# Altium schematic sheet P03_WiFi 2 of 2.SchDoc
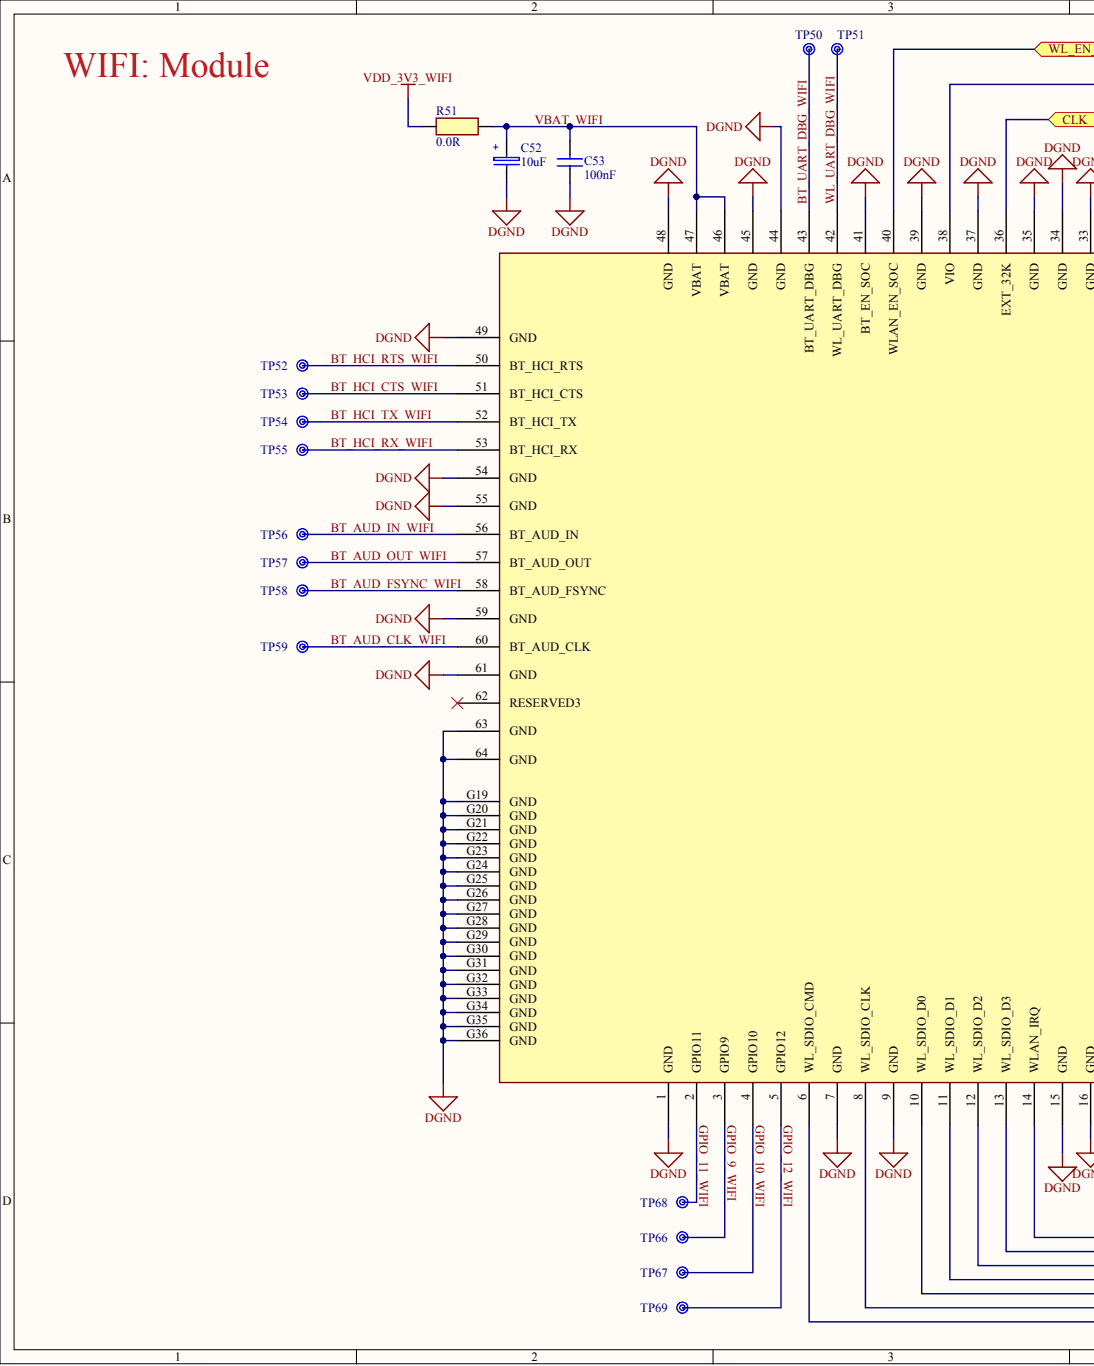
[diagram p0: P03_WiFi 2 of 2.SchDoc, left]
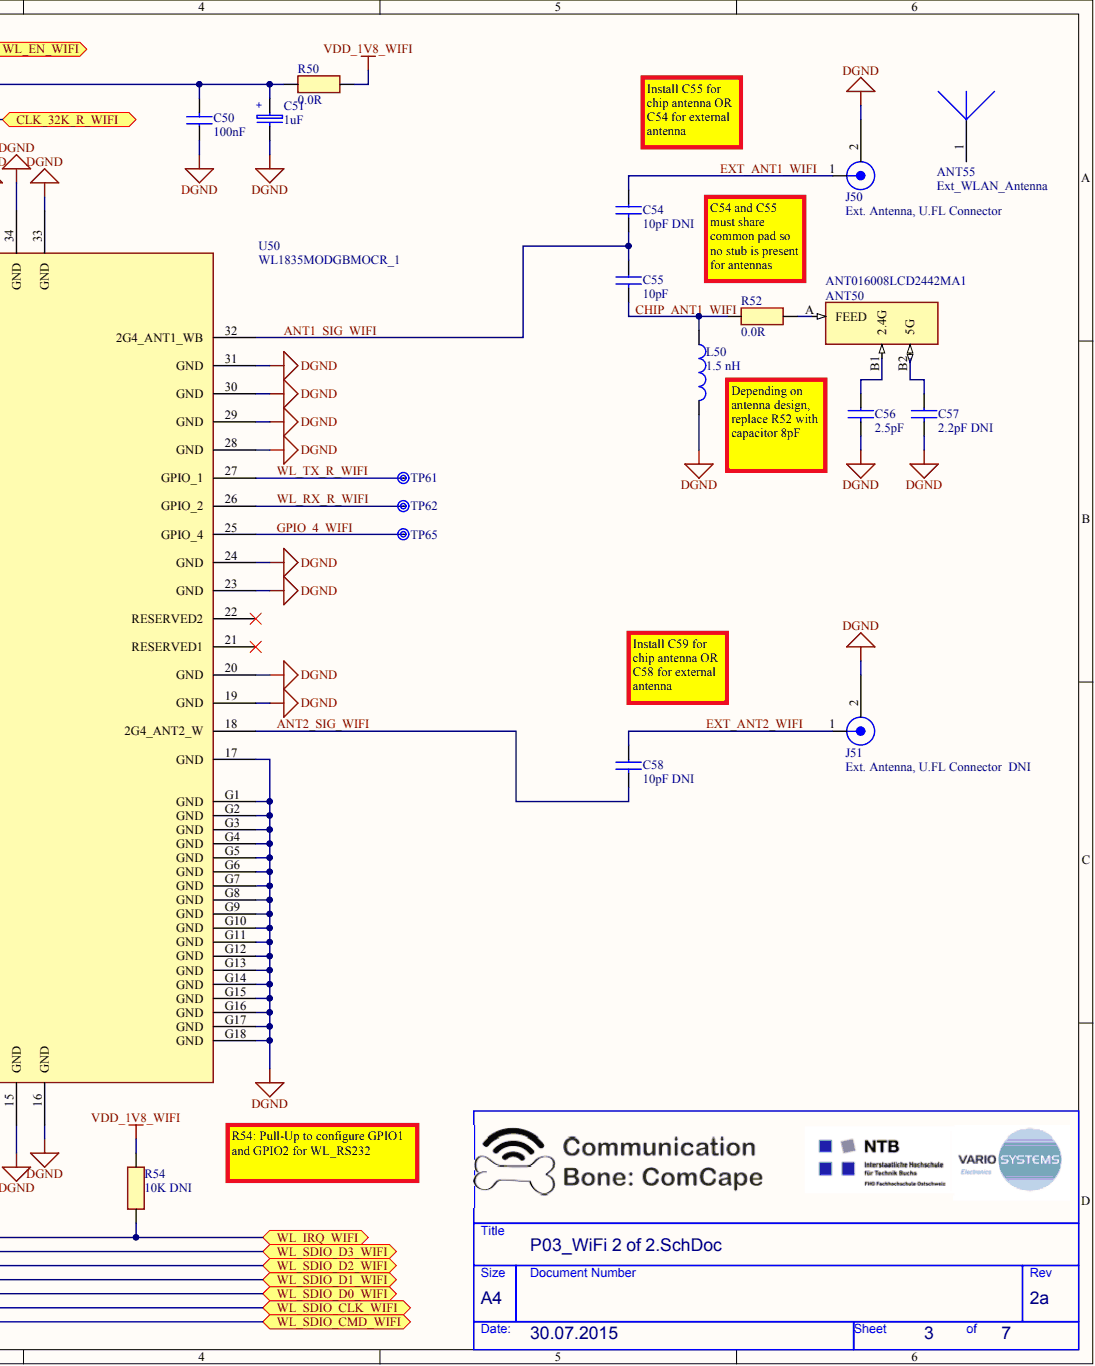
[diagram p1: P03_WiFi 2 of 2.SchDoc, right]

## Parts
ANT50  ANT016008LCD2442MA1  [ANT016008LCD2442MA1]
ANT55  Ext_WLAN_Antenna  (mechanical)
C50  100nF  [SM/C_0603]
C51  1uF  [SM/CP_3216]
C52  10uF  [SM/CP_3528]
C53  100nF  [SM/C_0603]
C54  10pF DNI  [SM/C_0402]
C55  10pF  [SM/C_0402]
C56  2.5pF  [SM_0402_WIFI]
C57  2.2pF DNI  [SM_0402_WIFI]
C58  10pF DNI  [SM/C_0402]
J50  Ext. Antenna, U.FL Connector  [U.FL_Ext-Ant-Connector]
J51  Ext. Antenna, U.FL Connector  DNI  [U.FL_Ext-Ant-Connector]
L50  1.5 nH  [SM/L_0402]
R50  0.0R  [SM/R_0603]
R51  0.0R  [SM/R_0603]
R52  0.0R  [SM/R_0402]
R54  10K DNI  value 10K  [SM/R_0603]
TP50  Testpoint mit Bohrung  [TP_mit_0.7mm_Bohrung]  (value not drawn)  (no BOM)
TP51  Testpoint mit Bohrung  [TP_mit_0.7mm_Bohrung]  (value not drawn)  (no BOM)
TP52  Testpoint mit Bohrung  [TP_mit_0.7mm_Bohrung]  (value not drawn)  (no BOM)
TP53  Testpoint mit Bohrung  [TP_mit_0.7mm_Bohrung]  (value not drawn)  (no BOM)
TP54  Testpoint mit Bohrung  [TP_mit_0.7mm_Bohrung]  (value not drawn)  (no BOM)
TP55  Testpoint mit Bohrung  [TP_mit_0.7mm_Bohrung]  (value not drawn)  (no BOM)
TP56  Testpoint mit Bohrung  [TP_mit_0.7mm_Bohrung]  (value not drawn)  (no BOM)
TP57  Testpoint mit Bohrung  [TP_mit_0.7mm_Bohrung]  (value not drawn)  (no BOM)
TP58  Testpoint mit Bohrung  [TP_mit_0.7mm_Bohrung]  (value not drawn)  (no BOM)
TP59  Testpoint mit Bohrung  [TP_mit_0.7mm_Bohrung]  (value not drawn)  (no BOM)
TP61  Testpoint mit Bohrung  [TP_mit_0.7mm_Bohrung]  (value not drawn)  (no BOM)
TP62  Testpoint mit Bohrung  [TP_mit_0.7mm_Bohrung]  (value not drawn)  (no BOM)
TP65  Testpoint mit Bohrung  [TP_mit_0.7mm_Bohrung]  (value not drawn)  (no BOM)
TP66  Testpoint mit Bohrung  [TP_mit_0.7mm_Bohrung]  (value not drawn)  (no BOM)
TP67  Testpoint mit Bohrung  [TP_mit_0.7mm_Bohrung]  (value not drawn)  (no BOM)
TP68  Testpoint mit Bohrung  [TP_mit_0.7mm_Bohrung]  (value not drawn)  (no BOM)
TP69  Testpoint mit Bohrung  [TP_mit_0.7mm_Bohrung]  (value not drawn)  (no BOM)
U50  WL1835MODGBMOCR_1  [WLAN_100Pin_MOC_E-13.4X13.3-N100_0.75-TOP]

## Ports
CLK_32K_R_WIFI, WL_EN_WIFI, WL_IRQ_WIFI, WL_SDIO_CLK_WIFI, WL_SDIO_CMD_WIFI, WL_SDIO_D0_WIFI, WL_SDIO_D1_WIFI, WL_SDIO_D2_WIFI, WL_SDIO_D3_WIFI

## Nets
DGND: C50.1 C51.N C52.N C53.1 C56.2 C57.2 J50.2 J51.2 L50.1 U50.1(GND) U50.7(GND) U50.9(GND) U50.15(GND) U50.16(GND) U50.17(GND) U50.19(GND) U50.20(GND) U50.23(GND) U50.24(GND) U50.28(GND) U50.29(GND) U50.30(GND) U50.31(GND) U50.33(GND) U50.34(GND) U50.35(GND) U50.37(GND) U50.39(GND) U50.41(BT_EN_SOC) U50.44(GND) U50.45(GND) U50.48(GND) U50.49(GND) U50.54(GND) U50.55(GND) U50.59(GND) U50.61(GND) U50.63(GND) U50.64(GND) U50.G1(GND) U50.G2(GND) U50.G3(GND) U50.G4(GND) U50.G5(GND) U50.G6(GND) U50.G7(GND) U50.G8(GND) U50.G9(GND) U50.G10(GND) U50.G11(GND) U50.G12(GND) U50.G13(GND) U50.G14(GND) U50.G15(GND) U50.G16(GND) U50.G17(GND) U50.G18(GND) U50.G19(GND) U50.G20(GND) U50.G21(GND) U50.G22(GND) U50.G23(GND) U50.G24(GND) U50.G25(GND) U50.G26(GND) U50.G27(GND) U50.G28(GND) U50.G29(GND) U50.G30(GND) U50.G31(GND) U50.G32(GND) U50.G33(GND) U50.G34(GND) U50.G35(GND) U50.G36(GND)
VDD_1V8_WIFI: R50.2 R54.2
VDD_3V3_WIFI: R51.1
ANT1_SIG_WIFI: C54.2 C55.1 U50.32(2G4_ANT1_WB)
ANT2_SIG_WIFI: C58.2 U50.18(2G4_ANT2_W)
BT_AUD_CLK_WIFI: TP59.1 U50.60(BT_AUD_CLK)
BT_AUD_FSYNC_WIFI: TP58.1 U50.58(BT_AUD_FSYNC)
BT_AUD_IN_WIFI: TP56.1 U50.56(BT_AUD_IN)
BT_AUD_OUT_WIFI: TP57.1 U50.57(BT_AUD_OUT)
BT_HCI_CTS_WIFI: TP53.1 U50.51(BT_HCI_CTS)
BT_HCI_RTS_WIFI: TP52.1 U50.50(BT_HCI_RTS)
BT_HCI_RX_WIFI: TP55.1 U50.53(BT_HCI_RX)
BT_HCI_TX_WIFI: TP54.1 U50.52(BT_HCI_TX)
BT_UART_DBG_WIFI: TP50.1 U50.43(BT_UART_DBG)
CHIP_ANT1_WIFI: C55.2 L50.2 R52.1
CLK_32K_R_WIFI: U50.36(EXT_32K) ; port CLK_32K_R_WIFI
EXT_ANT1_WIFI: C54.1 J50.1
EXT_ANT2_WIFI: C58.1 J51.1
GPIO_4_WIFI: TP65.1 U50.25(GPIO_4)
GPIO_9_WIFI: TP66.1 U50.3(GPIO9)
GPIO_10_WIFI: TP67.1 U50.4(GPIO10)
GPIO_11_WIFI: TP68.1 U50.2(GPIO11)
GPIO_12_WIFI: TP69.1 U50.5(GPIO12)
VBAT_WIFI: C52.P C53.2 R51.2 U50.46(VBAT) U50.47(VBAT)
WL_EN_WIFI: U50.40(WLAN_EN_SOC) ; port WL_EN_WIFI
WL_IRQ_WIFI: R54.1 U50.14(WLAN_IRQ) ; port WL_IRQ_WIFI
WL_RX_R_WIFI: TP62.1 U50.26(GPIO_2)
WL_SDIO_CLK_WIFI: U50.8(WL_SDIO_CLK) ; port WL_SDIO_CLK_WIFI
WL_SDIO_CMD_WIFI: U50.6(WL_SDIO_CMD) ; port WL_SDIO_CMD_WIFI
WL_SDIO_D0_WIFI: U50.10(WL_SDIO_D0) ; port WL_SDIO_D0_WIFI
WL_SDIO_D1_WIFI: U50.11(WL_SDIO_D1) ; port WL_SDIO_D1_WIFI
WL_SDIO_D2_WIFI: U50.12(WL_SDIO_D2) ; port WL_SDIO_D2_WIFI
WL_SDIO_D3_WIFI: U50.13(WL_SDIO_D3) ; port WL_SDIO_D3_WIFI
WL_TX_R_WIFI: TP61.1 U50.27(GPIO_1)
WL_UART_DBG_WIFI: TP51.1 U50.42(WL_UART_DBG)
NetANT50_A: ANT50.A R52.2
NetANT50_B1: ANT50.B1 C56.1
NetANT50_B2: ANT50.B2 C57.1
NetC50_2: C50.2 C51.P R50.1 U50.38(VIO)

Not connected: ANT55 1; U50 21(RESERVED1) 22(RESERVED2) 62(RESERVED3)

## Library data of the parts
ANT50 = ANT016008LCD2442MA1: 2.4 / 5 GHz Chip Antenna; Manufacturer: TDK Corporation; Component Kind: Standard; Datasheet: http://product.tdk.com/en/catalog/datasheets/rf_ant_ant016008lcd2442ma1_en.pdf; HTNr: ANT016008LCD2442MA1; Supplier 1: Digi-Key; Supplier Part Number 1: 445-15175-1-ND; Library Name: ComCape.SchLib
ANT55 = Ext_WLAN_Antenna: Wireless External Antenna 2.4 GHz; Supplier 1: Digi-Key; Supplier Part Number 1: 553-1826-ND; Library Name: ComCape.SchLib
C50 C53 = (no symbol name): SC CAP __0603 100n00 _16V ___10% X7R ___; Manufacturer: Generic Component; Part Number: 209336; Cust: Y; HTNr: take notice of key data; Tolerance: 10%; Voltage: 16V; Component Kind: Standard; Part Type: CAP-SMD\Keramik\0603; PCB Footprint: SM/C_0603; Std: STD; Supplier 1: Digi-Key; Supplier Part Number 1: 399-1096-1-ND; Value: 100nF; Library Name: ComCape.SchLib
C51 = SC CAP __3216 __1u00 _16V ___10% TAN ___: Manufacturer: Kemet; Part Number: 227555; Cust: Y; HTNr: T491A105K016AT; Tolerance: 10%; Voltage: 16V; Component Kind: Standard; Description: SC CAP __3216 __1u00 _16V ___10% TAN ___; Part Type: CAP-SMD\Tantal; Supplier 1: Digi-Key; Supplier Part Number 1: 399-3678-1-ND; Datasheet: S:\Datenb\DS-221192-000A.pdf; Library Name: ComCape.SchLib
C52 = SC CAP __3528 _10u00 _10V ___20% TAN ___: Manufacturer: Generic Component; Part Number: 208069; Cust: Y; HTNr: take notice of key data; Tolerance: 20%; Voltage: 10V; Component Kind: Standard; Description: SC CAP __3528 _10u00 _10V ___20% TAN ___; Part Type: CAP-SMD\Tantal; Std: STD; Supplier 1: Digi-Key; Supplier Part Number 1: 399-3707-1-ND; Library Name: ComCape.SchLib
C54 C55 C58 = SC CAP __0402 _10P00 _50V ___P25 C0G ___: Manufacturer: Generic Component; Part Number: 2013217; Cust: Y; HTNr: take notice of key data; Voltage: 50V; Component Kind: Standard; Description: SC CAP __0402 _10P00 _50V ___P25 C0G ___; Part Type: CAP-SMD\Keramik\0402; Std: STD; Supplier 1: Mouser; Supplier Part Number 1: 791-0402N100J500LT; Library Name: ComCape.SchLib
C56 = (no symbol name): CAP CER 2.2PF 50V NP0 0402; Component Kind: Standard; Supplier 1: Digi-Key; Supplier Part Number 1: 490-8092-1-ND; Library Name: ComCape.SchLib
C57 = 247227: SC CAP __0402 __2P20 _50V ___P25 NP0 ___; Manufacturer: Generic Component; Cust: Y; Database Table Name: Capacitor; HTNr: take notice of key data; Std: STD SET; Voltage: 50V; Component Kind: Standard; Part Number: 247227; Part Type: CAP-SMD\Keramik\0402; Library Name: Customer_Database.DBLib
J50 J51 = U.FL_BNC: U.FL_IPEX_0_6GHz_Ext-Ant-Connector; Manufacturer: Hirose Electric Co Ltd; HTNr: U.FL-R--SMT-1(01); Component Kind: Standard; Datasheet: http://media.digikey.com/pdf/Data%20Sheets/Hirose%20PDFs/UFL%20Series.pdf; DigiKey Part Number: H122041-ND; Supplier 1: Digi-Key; Supplier Part Number 1: H122041-ND; Library Name: ComCape.SchLib
L50 = IND_1.5nH: Inductor_1.5nH_0402; Component Kind: Standard; Supplier 1: Mouser; Supplier Part Number 1: 81-LQP15MN1N5W02D; Library Name: ComCape.SchLib
R50 R51 = SC RES __0603 __0R00 __0W1 _____ T100 __: Manufacturer: Generic Component; Part Number: 206748; Cust: Y; HTNr: take notice of key data; Power: 0.1W; Std: STD SET; Tolerance: 1%; Component Kind: Standard; Description: SC RES __0603 __0R00 __0W1 _____ T100 __; Part Type: RES-SMD\0603; PCB Footprint: SM/R_0603; Supplier 1: Digi-Key; Supplier Part Number 1: 1276-3510-1-ND; Value: 0.0R; Library Name: ComCape.SchLib
R52 = SC RES __0402 __0R00 0W063 _____ ____ __: Manufacturer: Generic Component; Part Number: 226229; Cust: Y; HTNr: take notice of key data; Power: 0.06W; Std: STD SET; Tolerance: 1%; Component Kind: Standard; Description: SC RES __0402 __0R00 0W063 _____ ____ __; Part Type: RES-SMD\0402; PCB Footprint: SM/R_0402; Supplier 1: Digi-Key; Supplier Part Number 1: 1276-3480-1-ND; Value: 0.0R; Library Name: ComCape.SchLib
R54 = SC RES __0603 _10K00 __0W1 ___1% T100 __: Manufacturer: Generic Component; Part Number: 200127; Cust: Y; HTNr: take notice of key data; Power: 0.1W; Std: STD SET; Tolerance: 1%; Component Kind: Standard; Description: SC RES __0603 _10K00 __0W1 ___1% T100 __; Part Type: RES-SMD\0603; PCB Footprint: SM/R_0603; Supplier 1: Digi-Key; Supplier Part Number 1: 1276-3485-1-ND; Library Name: ComCape.SchLib
TP50-TP59 TP61 TP62 TP65-TP69 = Testpoint mit Bohrung: TP_0.7mm_THRU; Library Name: ComCape.SchLib
U50 = WL1835MODGBMOCR_1: WLAN / BT Modul; Component Kind: Standard; Supplier 1: Digi-Key; Supplier Part Number 1: 296-38032-1-ND; Library Name: ComCape.SchLib
